# Altium schematic sheet Humidity Output.SchDoc
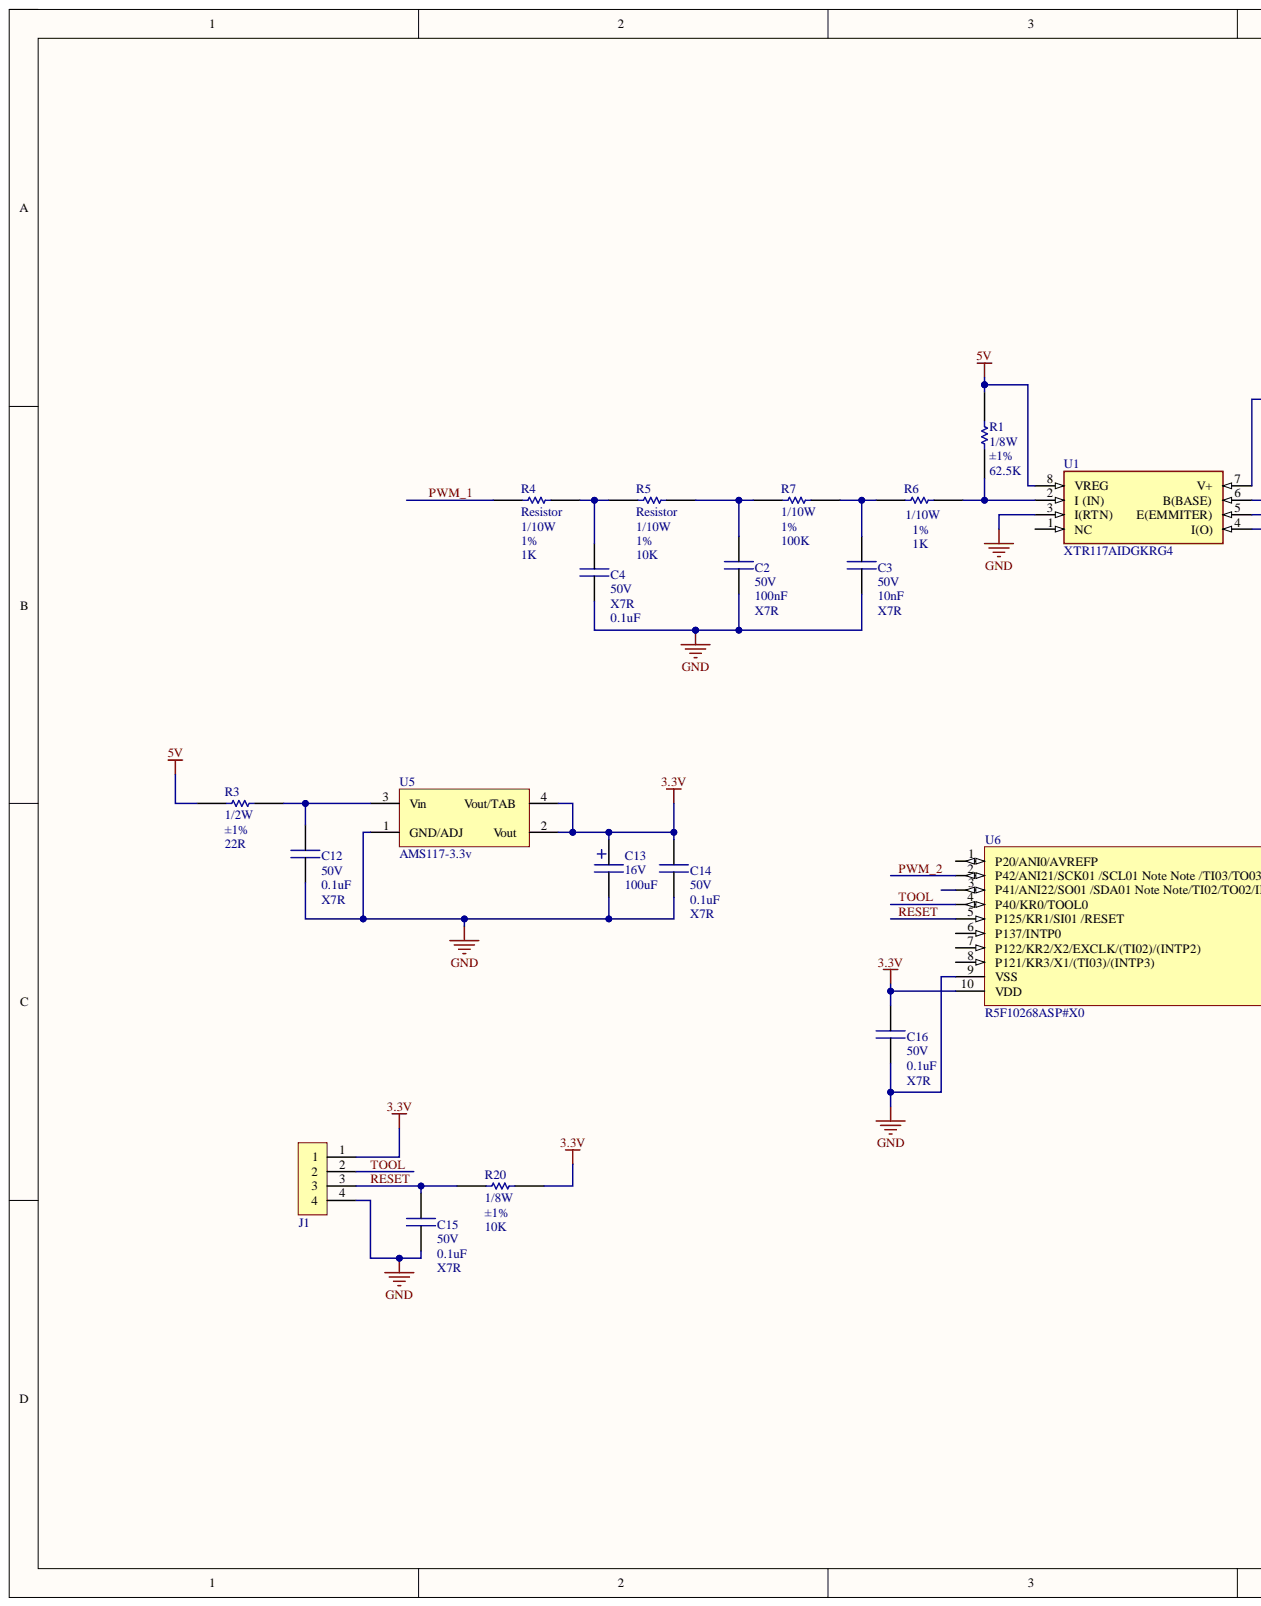
[diagram p0: Humidity Output.SchDoc, left]
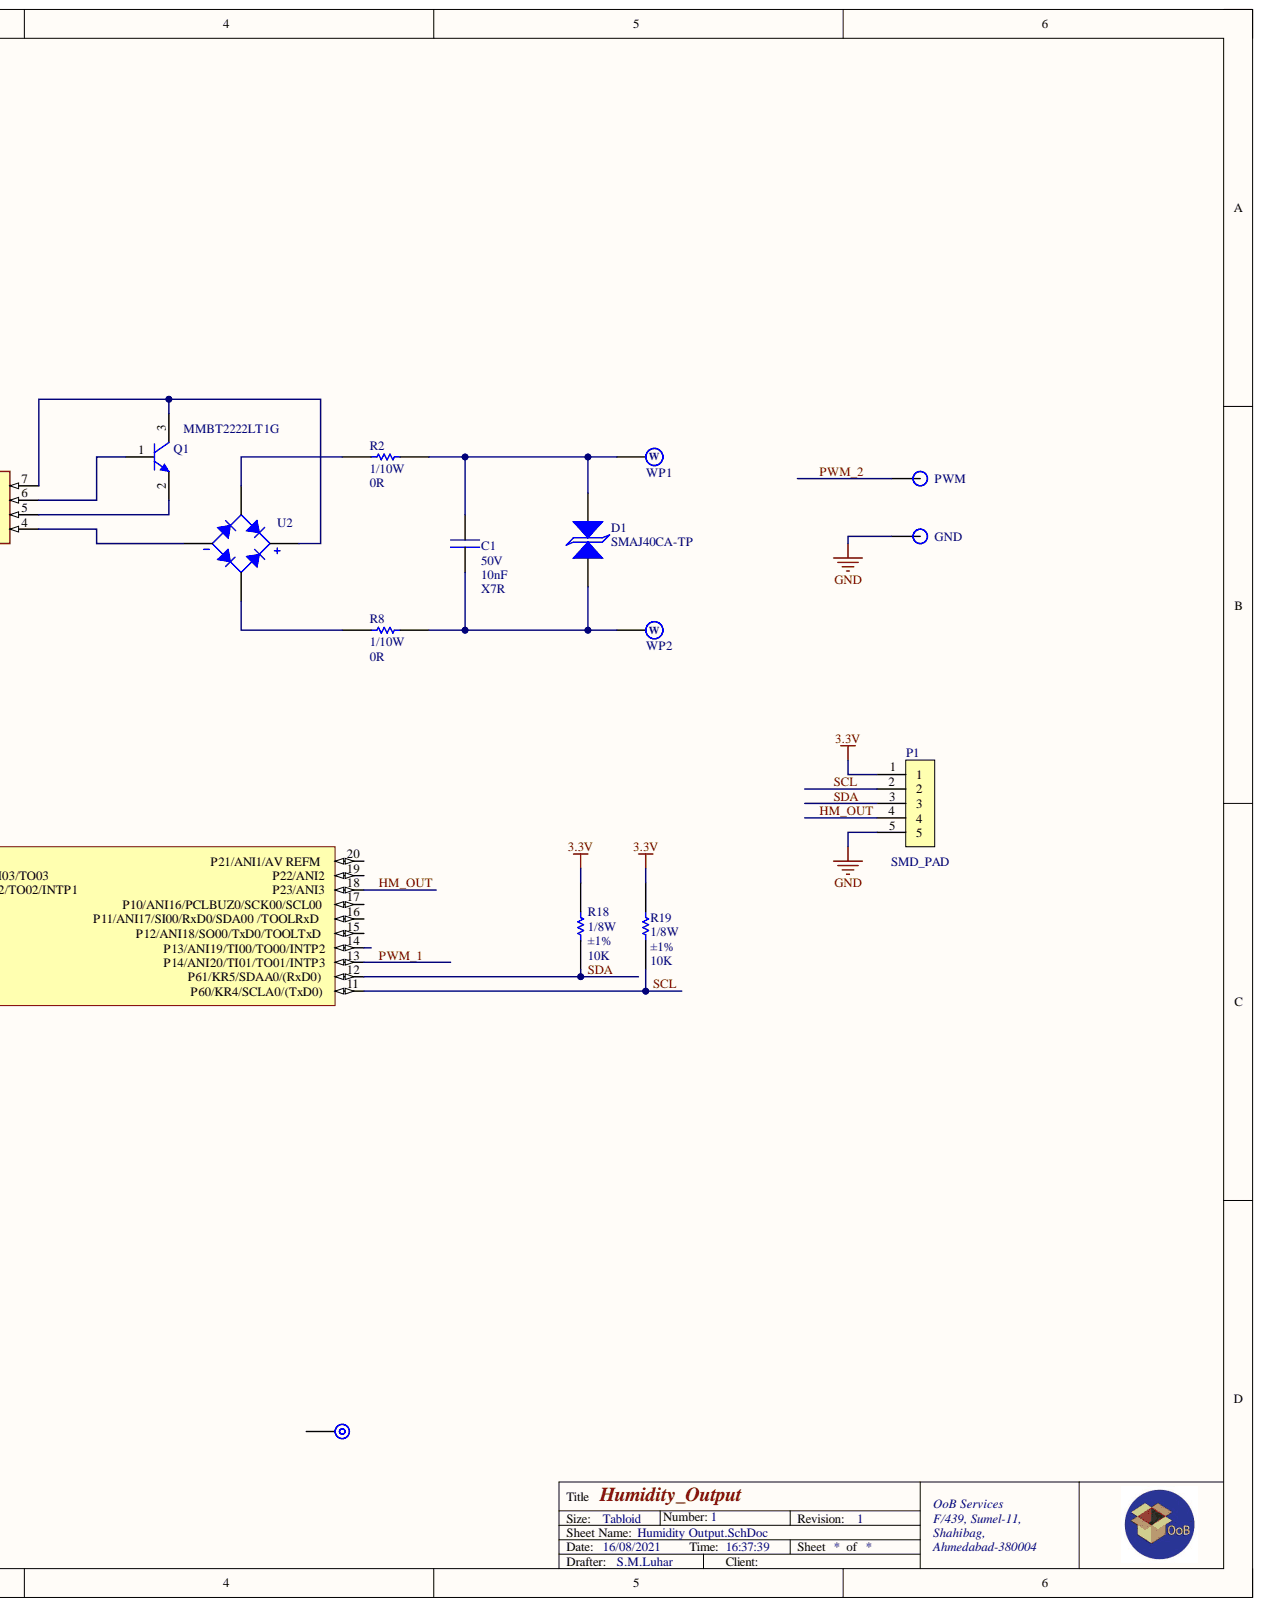
[diagram p1: Humidity Output.SchDoc, right]

## Parts
C1  value 10nF  Dielectric: X7R  Voltage: 50V  [CAPC1608X05_(0603)]  (hidden comment: Capacitor)
C2  value 100nF  Dielectric: X7R  Voltage: 50V  [CAPC1608X05_(0603)]  (hidden comment: Capacitor)
C3  value 10nF  Dielectric: X7R  Voltage: 50V  [CAPC1608X05_(0603)]  (hidden comment: Capacitor)
C4  value 0.1uF  Dielectric: X7R  Voltage: 50V  [CAPC1608X09_(0603)]  (hidden comment: Capacitor)
C12  value 0.1uF  Dielectric: X7R  Voltage: 50V  [CAPC2012X13_(0805)]  (hidden comment: Capacitor)
C13  value 100uF  Voltage: 16V  [Rubycon_16YXG100MEFC5X11_2/5TH_CAP - duplicate]  (hidden comment: Capacitor)
C14  value 0.1uF  Dielectric: X7R  Voltage: 50V  [CAPC2012X13_(0805)]  (hidden comment: Capacitor)
C15  value 0.1uF  Dielectric: X7R  Voltage: 50V  [CAPC2012X13_(0805)]  (hidden comment: Capacitor)
C16  value 0.1uF  Dielectric: X7R  Voltage: 50V  [CAPC2012X13_(0805)]  (hidden comment: Capacitor)
D1  SMAJ40CA-TP  [SMA]
GND  SMD-PAD  [2.5 SMD PAD -NC]  (value not drawn)
J1  61300411121  [MH_2.54mm_4_WURTH_61300411121]  (value not drawn)
MH1  MT-d3_D3mm-Mounting Hole-OOB  [MOUNTING_HOLE_3]  (value not drawn)
P1  SMD_PAD  [PAD_HUMIDITY_SENSOR]
PWM  SMD-PAD  [2.5 SMD PAD -NC]  (value not drawn)
Q1  MMBT2222LT1G  [SOT95P230X110-3N_(SOT23-3)]
R1  Resistor  value 62.5K  Tolerance: ±1%  Wattage: 1/8W  [RESC2113X06N_(0805)]
R2  Resistor  value 0R  Wattage: 1/10W  [RESC1608X06_(0603)]
R3  Resistor  value 22R  Tolerance: ±1%  Wattage: 1/2W  [RESC3216X06N]
R4  Resistor  value 1K  Tolerance: 1%  Wattage: 1/10W  [RESC1608X06_(0603)]
R5  Resistor  value 10K  Tolerance: 1%  Wattage: 1/10W  [RESC1608X06_(0603)]
R6  Resistor  value 1K  Tolerance: 1%  Wattage: 1/10W  [RESC1608X06_(0603)]
R7  Resistor  value 100K  Tolerance: 1%  Wattage: 1/10W  [RESC1608X06_(0603)]
R8  Resistor  value 0R  Wattage: 1/10W  [RESC1608X06_(0603)]
R18  Resistor  value 10K  Tolerance: ±1%  Wattage: 1/8W  [RESC2113X06N_(0805)]
R19  Resistor  value 10K  Tolerance: ±1%  Wattage: 1/8W  [RESC2113X06N_(0805)]
R20  Resistor  value 10K  Tolerance: ±1%  Wattage: 1/8W  [RESC2113X06N_(0805)]
U1  XTR117AIDGKRG4  [SOP65P490X110-8N_2]
U2  MB10FTR  [SMC Diode Solutions_MB10FTR_SMD4PIN]  (value not drawn)
U5  AMS117-3.3v  [SOT223]
U6  R5F10268ASP#X0  [TSOP65P640X120-20N]
WP1  WP-d0.8-D2mm-H2.5-WirePad-OOB  [Wirepad_d0.8_D2_H2.5]  (value not drawn)
WP2  WP-d0.8-D2mm-H2.5-WirePad-OOB  [Wirepad_d0.8_D2_H2.5]  (value not drawn)

## Nets
3.3V: C13.1 C14.1 C16.1 J1.1 P1.1 R18.2 R19.2 R20.2 U5.2(Vout) U5.4(Vout/TAB) U6.10(VDD)
5V: R1.2 R3.2 U1.8(VREG)
GND: C2.2 C3.2 C4.2 C12.2 C13.2 C14.2 C15.2 C16.2 GND.1 J1.4 P1.5 U1.3(I(RTN)) U5.1(GND/ADJ) U6.9(VSS)
HM_OUT: P1.4 U6.18(P23/ANI3)
PWM_1: R4.1 U6.13(P14/ANI20/TI01/TO01/INTP3)
PWM_2: PWM.1 U6.2(P42/ANI21/SCK01_/SCL01_Note_Note_/TI03/TO03)
RESET: C15.1 J1.3 R20.1 U6.5(P125/KR1/SI01_/RESET)
SCL: P1.2 R19.1 U6.11(P60/KR4/SCLA0/(TxD0))
SDA: P1.3 R18.1 U6.12(P61/KR5/SDAA0/(RxD0))
TOOL: J1.2 U6.4(P40/KR0/TOOL0)
NetC1_1: C1.1 D1.1 R8.1 WP2.1
NetC1_2: C1.2 D1.2 R2.1 WP1.1
NetC2_1: C2.1 R5.2 R7.1
NetC3_1: C3.1 R6.1 R7.2
NetC4_1: C4.1 R4.2 R5.1
NetC12_1: C12.1 R3.1 U5.3(Vin)
NetQ1_1: Q1.1 U1.6(B(BASE))
NetQ1_2: Q1.2 U1.5(E(EMMITER))
NetQ1_3: Q1.3 U1.7(V+) U2.3
NetR1_1: R1.1 R6.2 U1.2(I_(IN))
NetR2_2: R2.2 U2.1
NetR8_2: R8.2 U2.2
NetU1_4: U1.4(I(O)) U2.4

Not connected: MH1 MT; U1 1(NC); U6 1(P20/ANI0/AVREFP) 3(P41/ANI22/SO01_/SDA01_Note_Note/TI02/TO02/INTP1) 6(P137/INTP0) 7(P122/KR2/X2/EXCLK/(TI02)/(INTP2)) 8(P121/KR3/X1/(TI03)/(INTP3)) 14(P13/ANI19/TI00/TO00/INTP2) 15(P12/ANI18/SO00/TxD0/TOOLTxD) 16(P11/ANI17/SI00/RxD0/SDA00_/TOOLRxD) 17(P10/ANI16/PCLBUZ0/SCK00/SCL00) 19(P22/ANI2) 20(P21/ANI1/AV_REFM)

## Library data of the parts
C1 C3 = CAP-0603-10nF-50V-X7R-CL-Samsung: CAP CER 10000PF 50V X7R 0603; Component Status: Active; Lib Standard: 1.0; MFG: Samsung Electro-Mechanics; MFG PN: CL10B103KB8NNNC; MFG Series: CL; Owner: OoB Services; QA Status: Created; Component Kind: Standard; Creation Date: 21/12/2020; Library Name: OoB_Integrated_Lib.IntLib; Engineer Created: AC
C2 = CAP-0603-100nF-M-Taiyo Yuden: CAP CER 100nf 16V X5R 0201; Component Status: Active; Lib Standard: 1.0; MFG PN: EMK063BJ103KP-F; MFG Series: M; Owner: OoB Services; QA Status: Created; Component Kind: Standard; Creation Date: 21/05/2021; Library Name: OoB_Integrated_Lib.IntLib; MFG: Taiyo Yuden; Engineer Created: SL
C4 = CAP-0603-0.1uF-50V-X7R-na-AVX Corporation: 0.1µF ±5% 50V Ceramic Capacitor X7R 0603; Component Status: ACTIVE; Lib Standard: 1.0; MFG PN: 06035C104JAT2A; MFG Series: NA; Owner: OoB Services; QA Status: Created; Component Kind: Standard; Creation Date: 21/12/2020; Library Name: OoB_Integrated_Lib.IntLib; MFG: AVX Corporation; Engineer Created: PP
C12 C14-C16 = CAP-0805-0.1uF-50V-X7R-CL-Samsung: CAP CER 0.1uF 50V X7R 0805; Component Status: Active; Lib Standard: 1.0; MFG: Samsung Electro-Mechanics; MFG PN: CL21B104KBCNNNC; MFG Series: CL; Owner: OoB Services; QA Status: Created; Component Kind: Standard; Creation Date: 11/1/2021; Library Name: OoB_Integrated_Lib.IntLib; Engineer Created: AC
C13 = CAP-TH_2/5-100uF-16V-±20%-YXG-Rubycon: CAP ALUM 100UF 20% 16V RADIAL; Component Status: Active; Lib Standard: 1.0; MFG PN: 16YXG100MEFC5X11; MFG Series: YXG; Owner: OoB Services; QA Status: Created; Component Kind: Standard; Creation Date: 1/2/2021; Library Name: OoB_Integrated_Lib.IntLib; MFG: Rubycon; Engineer Created: AC
D1 = D-TVS-40V-64.5V-TVS DIODE 40V 64.5V DO214AC-Bourns Inc.: TVS DIODE 40V 64.5V DO214AC; Component Status: In Dev/QA; Lib Standard: 1.0; Owner: OoB Services; QA Status: Created; Component Kind: Standard; Library Name: OoB_Integrated_Lib.IntLib; MFG: Bourns Inc.; MFG PN: SMAJ40CA-TP; Reverse Voltage: 40V; Engineer Created: SL
J1 = CON-MH-2.54mm-4x1-Wurth_1: CONN HEADER VERT 4POS 2.54MM; Component Status: Active; Current Capacity: 3A; Lib Standard: 1.0; MFG: Würth Elektronik; Number of Positions: 4; Owner: OoB Services; QA Status: Created; Component Kind: Standard; Creation Date: 22/12/2020; Library Name: OoB_Integrated_Lib.IntLib; MFG PN: 61300411121; MFG Series: -; Number of Rows: 1; Pitch: 2.54mm; Engineer Created: PP
MH1 = MT-d3_D3mm-Mounting Hole-OOB: MOUNTING HOLE; Component Kind: Standard; Library Name: OoB_Integrated_Lib.IntLib
P1 = SMD_PAD_HUMIDITY SENSOR: SMD PAD HUMIDITY SENSOR; Component Kind: Standard; Library Name: Schematic_Symbole_Lib.SchLib
Q1 = T-NPN-40V-1A-SOT-23-ON Semiconductor: TRANS NPN 40V 1A SOT-23; Component Status: Active; Lib Standard: 1.0; Owner: OoB Services; QA Status: Created; Component Kind: Standard; Creation Date: 17/05/2021; Current: 1A; Library Name: OoB_Integrated_Lib.IntLib; MFG: ON Semiconductor; MFG PN: MMBT2222LT1G; MFG Series: -; Voltage: 40V; Engineer Created: SL
R1 = RES-0805-140K=-1%-1/8W-YAGEO: RES SMD 140K OHM 1% 1/8W 0805; Component Status: Active; Lib Standard: 1.0; MFG: YAGEO; MFG PN: RC0805FR-07140KL; MFG Series: RC_L; Owner: OoB Services; QA Status: Created; Component Kind: Standard; Creation Date: 17/05/2021; Library Name: OoB_Integrated_Lib.IntLib; Engineer Created: SL
R2 R8 = RES-0603-0R-1/10W-ERJ-Panasonic: RES SMD 0 OHM JUMPER 1/10W 0603; Component Status: Active; Lib Standard: 1.0; MFG: Panasonic Electronic Components; MFG PN: ERJ-3GEY0R00V; MFG Series: ERJ; Owner: OoB Services; QA Status: Created; Component Kind: Standard; Creation Date: 21/12/2020; Library Name: OoB_Integrated_Lib.IntLib; Engineer Created: AC
R3 = RES-1206-22R-22R-1%-TE Connectivity Passive Product: RES SMD  1206 22R 1%; Component Status: Active; Lib Standard: 1.0; MFG PN: CRGP1206F22R; MFG Series: CRGP; Owner: OoB Services; QA Status: Created; Component Kind: Standard; Creation Date: 19/05/2021; Library Name: OoB_Integrated_Lib.IntLib; MFG: TE Connectivity Passive Product; Engineer Created: SL
R4 R6 = RES-0603-1K-1/10W-1%-CRCW-C-Vishay: RES SMD 1K OHM 1% 1/10W 0603; Component Status: In Dev/QA; Lib Standard: 1.0; MFG: Vishay Dale; MFG PN: CRCW06031K00FKEAC; MFG Series: CRCW-C; Owner: OoB Services; QA Status: Created; Component Kind: Standard; Library Name: OoB_Integrated_Lib.IntLib; Engineer Created: PP
R5 = RES-0603-10K-1/10W-1%-RC-Yageo: RES SMD 10K OHM 1% 1/10W 0603; Component Status: Active; Lib Standard: 1.0; MFG: Yageo; MFG PN: RC0603FR-0710KL; MFG Series: RC; Owner: OoB Services; QA Status: Created; Component Kind: Standard; Creation Date: 21/12/2020; Library Name: OoB_Integrated_Lib.IntLib; Value: 10K; Engineer Created: AC
R7 = RES-0603-100K-1/10W-1%-ERJ-Panasonic: RES SMD 100K OHM 1% 1/10W 0603; Component Status: Active; Lib Standard: 1.0; MFG: Panasonic Electronic Components; MFG PN: ERJ-3EKF1003V; MFG Series: ERJ; Owner: OoB Services; QA Status: Created; Component Kind: Standard; Creation Date: 21/12/2020; Library Name: OoB_Integrated_Lib.IntLib; Engineer Created: AC
R18-R20 = RES-0805-10K-1/8W-±1%-RC0805FR-0710KL-Yageo: RES 10K OHM 1% 1/8W 0805; Component Status: Active; Lib Standard: 1.0; MFG: Yageo; Owner: OoB Services; QA Status: Created; Component Kind: Standard; Creation Date: 10/03/2021; Library Name: OoB_Integrated_Lib.IntLib; MFG PN: RC0805FR-0710KL; Engineer Created: SL
U1 = IC-CURRENT TX-8VSOP=TEXAS INSTRUMENT: IC CMOS 1 CIRCUIT SOT23-5; Component Status: In Dev/QA; Lib Standard: 1.0; Owner: OoB Services; QA Status: Created; Component Kind: Standard; Creation Date: 17/05/2021; MFG: TEXAS INSTRUMENT; MFG PN: XTR117AIDGKRG4; Engineer Created: SL; Library Name: Schematic_Symbole_Lib.SchLib
U2 = IC-BR-SMD_MBF-SMC Diode Solutions_MB10FTR: BRIDGE RECT 1PHASE 1KV 500MA MBF; Component Status: Active; Forward Voltage: 1.1V; Lib Standard: 1.0; Owner: OoB Services; QA Status: Created; Component Kind: Standard; Creation Date: 14/02/2021; Forward current: 500mA; Library Name: OoB_Integrated_Lib.IntLib; MFG: SMC Diode Solutions; MFG PN: MB10FTR; MFG Series: -; Reverse Voltage: 1KV; Engineer Created: SM
U5 = IC- VREG- REG 3.3 V SOT223-RoHs Compliant: IC REG K 3.3 V SOT223; Component Status: In Dev/QA; Lib Standard: 1.0; MFG PN: AMS1117-3.3V; MFG Series: NA; Owner: OoB Services; QA Status: Created; Component Kind: Standard; Library Name: OoB_Integrated_Lib.IntLib; MFG: RoHs Compliant; Release date: 09/03/2021; Engineer Created: SL
U6 = IC-MCU-16BIT-8KB-FLASH-20LSSOP-Renesas Electronics America Inc: IC MCU 16BIT 8KB FLASH 20LSSOP; Component Status: Active; Lib Standard: 1.0; Owner: OoB Services; QA Status: Created; Component Kind: Standard; Creation Date: 21/04/21; Library Name: OoB_Integrated_Lib.IntLib; MFG: Renesas Electronics America Inc; MFG PN: R5F10268ASP#X0; Engineer Created: SL
GND PWM = SMD-PAD: Component Kind: Standard; Library Name: OoB_Integrated_Lib.IntLib
WP1 WP2 = WP-d0.8-D2mm-H2.5-WirePad-OOB: Component Kind: Standard; Library Name: OoB_Integrated_Lib.IntLib
